# Altium schematic sheet IsolatedPowerSupplyMB-Rev2b.SchDoc(IsolatedPowerSupplyMB-Rev2b)
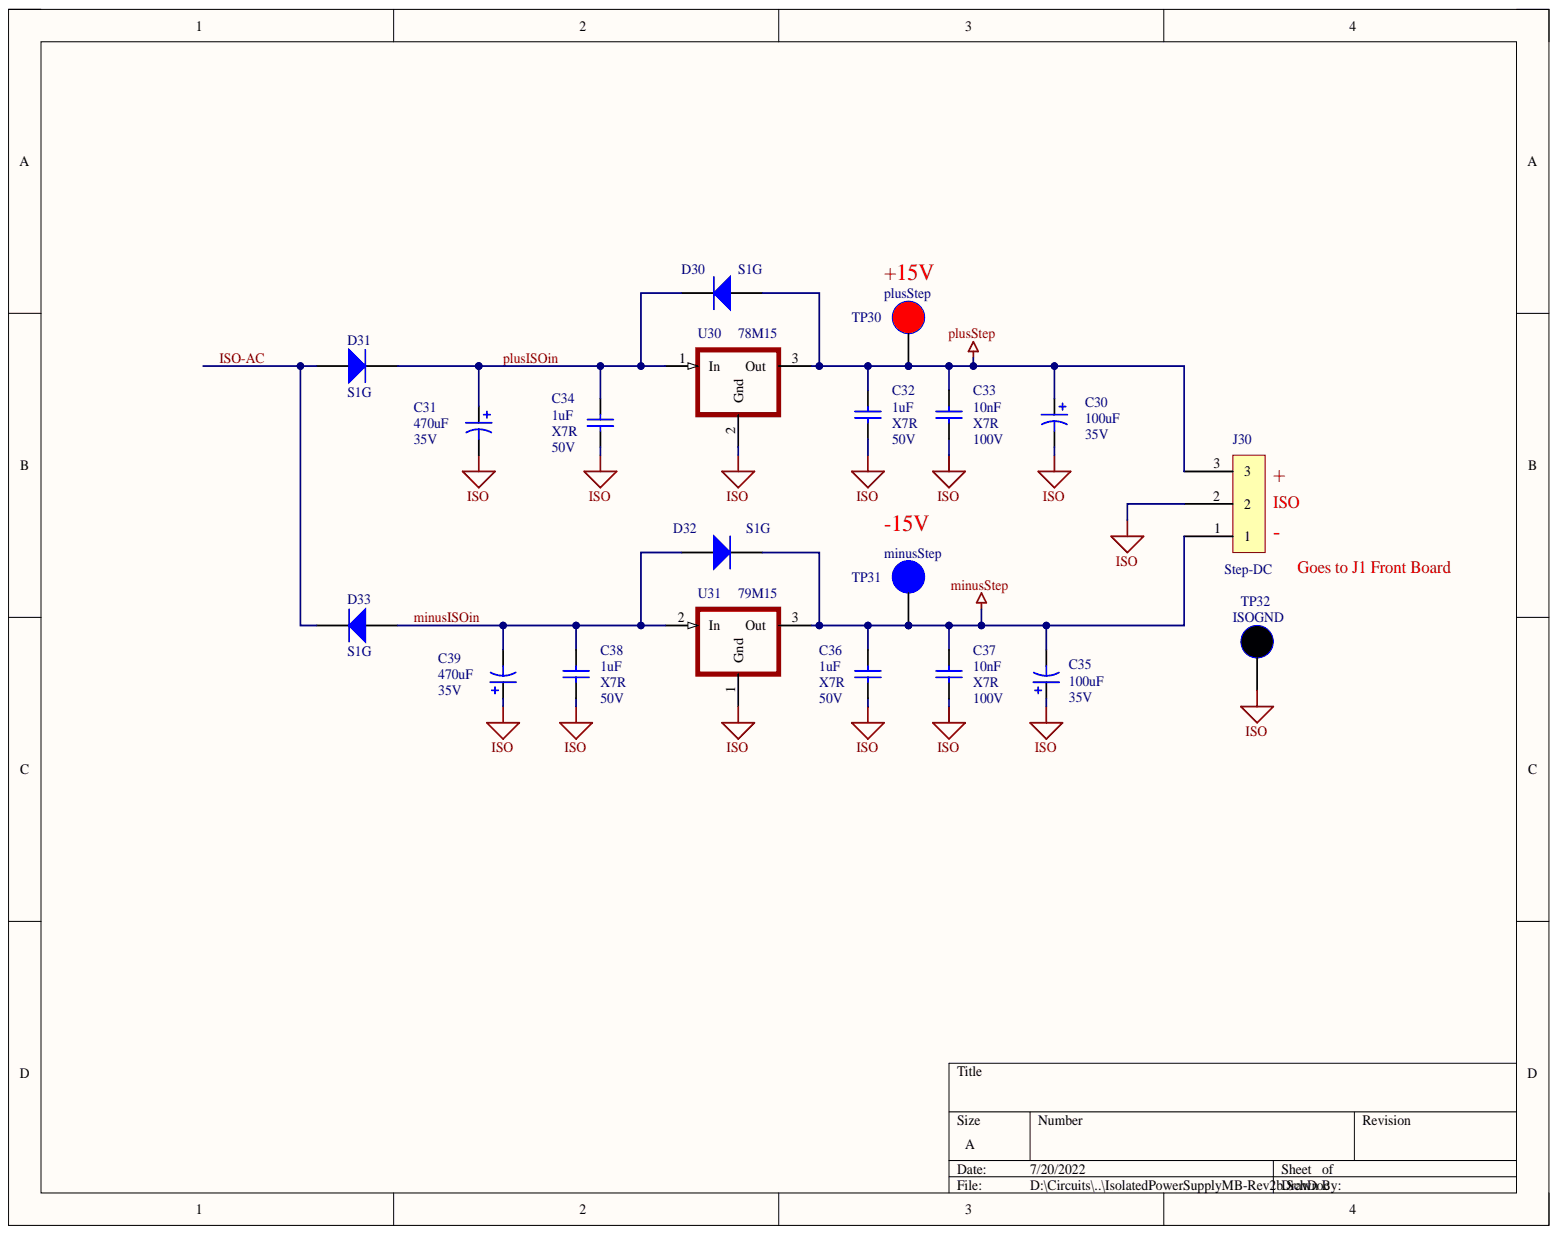
[diagram p0: IsolatedPowerSupplyMB-Rev2b.SchDoc]

## Parts
C30  100uF  Voltage: 35V  [CAPPR2.5-6.3X10]
C31  470uF  [CAPPR5-10x12.5-STEP]
C32  1uF  Dielectric: X7R  Voltage: 50V  [CAPC2012M]
C33  10nF  Dielectric: X7R  Voltage: 100V  [CAPC2012M]
C34  1uF  Dielectric: X7R  Voltage: 50V  [CAPC2012M]
C35  100uF  Voltage: 35V  [CAPPR2.5-6.3X10]
C36  1uF  Dielectric: X7R  [CAPC2012M]
C37  10nF  Dielectric: X7R  Voltage: 100V  [CAPC2012M]
C38  1uF  Dielectric: X7R  Voltage: 50V  [CAPC2012M]
C39  470uF  [CAPPR5-10x12.5-STEP]
D30  S1G  [SMA-D]
D31  S1G  [SMA-D]
D32  S1G  [SMA-D]
D33  S1G  [SMA-D]
J30  Step-DC  [DTA-100-3]
TP30  plusStep  [TP-.038]
TP31  minusStep  [TP-.038]
TP32  ISOGND  [TP-.038]
U30  78M15  [DPAK-M]
U31  79M15  [DPAK-M]

## Nets
ISO: C30.2 C31.2 C32.2 C33.2 C34.2 C35.1 C36.2 C37.2 C38.2 C39.1 J30.2 TP32.1 U30.2 U31.1
minusStep: C35.2 C36.1 C37.1 D32.2 J30.1 TP31.1 U31.3
plusStep: C30.1 C32.1 C33.1 D30.1 J30.3 TP30.1 U30.3
ISO-AC: D31.1 D33.2
minusISOin: C38.1 C39.2 D32.1 D33.1 U31.2
plusISOin: C31.1 C34.1 D30.2 D31.2 U30.1

## Library data of the parts
C30 C35 = Cap Pol1: CAP ALUM 100UF 20% 35V THRU HOLE; Manufacturer: Rubycon; Manufacturer Part Number: 35YXJ100M6.3X11; Impedance: 220mR; Lead Diameter: 0.5mm; Leakage Current: 3uA; Life (Hours): 7000Hour; Polarity: Polar; Ripple Current: 400mA; Capacitance: 100uF; Case/Package: Radial; Component Kind: Standard; Diameter: 6.3mm; Height: 11mm; Height - Seated (Max): 0.492inch; Lead Pitch: 2.5mm; Library Name: Miscellaneous Devices.IntLib; Max Operating Temperature: 105°C; Min Operating Temperature: -40°C; Mount: Through Hole; Package Quantity: 3000; Packaging: Bulk; Radiation Hardening: No; Signal Integrity: Cap Pol; Simulation: CAP; Supplier: Digi-Key; Supplier Part Number: 1189-2260-ND; Termination: Radial; Tolerance: 20%; Value: 100uF; Voltage Rating: 35V
C31 C39 = Cap Pol3: CAP ALUM 470UF 20% 35V RADIAL; Manufacturer: Rubycon; Manufacturer Part Number: 35ZL470MEFC10X20; Dielectric Material: Aluminium; Impedance: 23mR; Lead Diameter: 0.6mm; Leakage Current: 3uA; Life (Hours): 4000Hour; Ripple Current: 1.82A; Capacitance: 470uF; Case/Package: Radial; Component Kind: Standard; Diameter: 10mm; Height: 20mm; Height - Seated (Max): 0.866inch; Lead Free: Lead Free; Lead Pitch: 5mm; Library Name: Miscellaneous Devices.IntLib; Max Operating Temperature: 105°C; Min Operating Temperature: -40°C; Mount: Through Hole; Package Quantity: 1000; Packaging: Bulk; Radiation Hardening: No; REACH SVHC: Unknown; Signal Integrity: Cap Pol; Simulation: CAP; Supplier: Digi-Key; Supplier Part Number: 35ZL470MEFC10X20-ND; Termination: Radial; Tolerance: 20%; Value: 470uF; Voltage: 35V; Voltage Rating: 35V
C32 C34 C36 C38 = Cap: CAP CER 1UF 50V X7R 0805; Manufacturer: Samsung; Manufacturer Part Number: CL21B105KBFNNNE; Dielectric Material: Ceramic; Tolerance: 10%; Capacitance: 1uF; Case Code (Imperial): 0805; Case Code (Metric): 2012; Case/Package: 0805; Component Kind: Standard; Construction: Flat; Depth: 1.25mm; Height: 1.25mm; Length: 2mm; Library Name: Miscellaneous Devices.IntLib; Max Operating Temperature: 125°C; Min Operating Temperature: -55°C; Mount: Surface Mount; Number of Pins: 2; Package Quantity: 1; Packaging: Tape and Reel; Radiation Hardening: No; REACH SVHC: No SVHC; RoHS Compliant: Yes; Series: CL; Signal Integrity: Cap; Simulation: CAP; Supplier: Digi-Key; Supplier Part Number: 1276-1029-6-ND; Termination: SMD/SMT; Thickness: 0.053inch; Value: 1uF; Voltage Rating: 50V; Voltage Rating (DC): 50V; Width: 0.049inch
  C36: Voltage: 50V
C33 C37 = Cap: CAP CER 10NF 100V X7R 0805; Manufacturer: Samsung; Manufacturer Part Number: CL21B103KCANNNC; Dielectric Material: Ceramic; Dissipation Factor: 2.5%; Tolerance: 10%; Capacitance: 10nF; Case Code (Imperial): 0805; Case Code (Metric): 2012; Case/Package: 0805; Component Kind: Standard; Construction: Flat; Contact Plating: Tin; Depth: 1.25mm; Height: 0.65mm; Length: 2mm; Library Name: Miscellaneous Devices.IntLib; Material: Ceramic; Max Operating Temperature: 125°C; Min Operating Temperature: -55°C; Mount: Surface Mount; Number of Pins: 2; Package Quantity: 1; Packaging: Tape and Reel; Radiation Hardening: No; REACH SVHC: No SVHC; RoHS Compliant: Yes; Series: CL; Signal Integrity: Cap; Simulation: CAP; Supplier: Digi-Key; Supplier Part Number: 1276-1249-6-ND; Termination: SMD/SMT; Thickness: 0.03inch; Value: 10nF; Voltage Rating: 100V; Voltage Rating (DC): 100V; Width: 0.049inch
D30-D33 = Diode 1N4002: Diode Switching 400V 1A 2-Pin DO-214AC T/R; Manufacturer: ON Semiconductor / Fairchild; Capacitance: 12pF; Element Configuration: Single; Forward Voltage: 1.1V; Max Forward Surge Current (Ifsm): 30A; Max Surge Current: 40A; Peak Reverse Current: 1uA; Polarity: Standard; Power Dissipation: 1.4W; Recovery Time: 1800ns; Reverse Recovery Time: 1.8us; Average Rectified Current: 1A; Case/Package: SMA; Component Kind: Standard; ComponentLink1Description: Manufacturer Link; ComponentLink1URL: http://www.fairchildsemi.com/; ComponentLink2Description: Datasheet; ComponentLink2URL: http://www.fairchildsemi.com/ds/1N%2F1N4001.pdf; Contact Plating: Tin,Matte; Current Rating: 1A; Forward Current: 1A; Height: 2.2mm; Lead Free: Lead Free; Length: 4.75mm; Library Name: Miscellaneous Devices.IntLib; Manufacturer Part Number: S1G; Max Operating Temperature: 150°C; Max Repetitive Reverse Voltage (Vrrm): 400V; Max Reverse Voltage (DC): 400V; Min Operating Temperature: -55°C; Mount: Surface Mount; Number of Pins: 2; Output Current: 1A; Package Quantity: 1; Packaging: Tape and Reel; Peak Non-Repetitive Surge Current: 30A; Radiation Hardening: No; REACH SVHC: No SVHC; Reverse Voltage: 400V; Signal Integrity: 1N4002; Simulation: 1N4002; Supplier: Digi-Key; Supplier Part Number: S1GFSCT-ND; Value: S1G; Voltage Rating (DC): 400V; Weight: 0.106g; Width: 2.95mm
J30 = 3-Pin: 2.54mm 3 pin; Manufacturer: TE Connectivity; Manufacturer Part Number: 640456-3; Approvals: CSA; Backwall/Post Interruptions: No (Without); Circuit Application: Signal; Connector System: Wire-to-Board; Connector Type: Connector,Pin,Plug,Header; Contact Current Rating: 5A; Contact Post Length: 3.56mm; Contact Resistance: 6mR; Contact Style: Straight; Gender: Male; Mating Connector Lock: Yes (With); Max Voltage Rating (AC): 250V; Number of Contacts: 3; PCB Mount Retention: Without; PCB Mounting Orientation: Vertical; PCB Thickness: 0.063inch; Plating Thickness: 3.81um; Tail Length: 0.14inch; Value: 640456-3; Category: Connectors; Color: Beige,White; Component Kind: Standard; ComponentLink1Description: http://www.farnell.com/datasheets/2043229.pdf?src-supplier=Farnell; ComponentLink1URL: http://www.farnell.com/datasheets/2043229.pdf?src-supplier=Farnell; ComponentLink2Description: http://www.farnell.com/datasheets/2043229.pdf?src-supplier=Element14; ComponentLink2URL: http://www.farnell.com/datasheets/2043229.pdf?src-supplier=Element14; ComponentLink3Description: http://www.farnell.com/datasheets/2043229.pdf?src-supplier=Newark; ComponentLink3URL: http://www.farnell.com/datasheets/2043229.pdf?src-supplier=Newark; ComponentLink4Description: https://www.te.com/commerce/DocumentDelivery/DDEController?Action=srchrtrv&DocNm=640456&DocType=Customer+Drawing&DocLang=English&src-supplier=Digi-Key; ComponentLink4URL: https://www.te.com/commerce/DocumentDelivery/DDEController?Action=srchrtrv&DocNm=640456&DocType=Customer+Drawing&DocLang=English&src-supplier=Digi-Key; ComponentLink5Description: https://datasheet.ciiva.com/11746/0900766b80027008-11746172.pdf?src-supplier=RSComponents; ComponentLink5URL: https://datasheet.ciiva.com/11746/0900766b80027008-11746172.pdf?src-supplier=RSComponents; ComponentLink6Description: https://datasheet.ciiva.com/6005/1712135-6005440.pdf?src-supplier=Farnell; ComponentLink6URL: https://datasheet.ciiva.com/6005/1712135-6005440.pdf?src-supplier=Farnell; ComponentLink7Description: https://datasheet.ciiva.com/19474/613940-19474164.pdf?src-supplier=Jameco; ComponentLink7URL: https://datasheet.ciiva.com/19474/613940-19474164.pdf?src-supplier=Jameco; ComponentLink8Description: https://datasheet.ciiva.com/16456/eng-ds-82056-mta-0608-16456886.pdf?src-supplier=Future+Electronics; ComponentLink8URL: https://datasheet.ciiva.com/16456/eng-ds-82056-mta-0608-16456886.pdf?src-supplier=Future+Electronics; ComponentLink9Description: https://datasheet.ciiva.com/14156/04384301-14156821.pdf?src-supplier=Distrelec; ComponentLink9URL: https://datasheet.ciiva.com/14156/04384301-14156821.pdf?src-supplier=Distrelec; ComponentLink10Description: https://datasheet.ciiva.com/16656/eng-ds-82056-mta-0608-16656523.pdf?src-supplier=Avnet+Europe; ComponentLink10URL: https://datasheet.ciiva.com/16656/eng-ds-82056-mta-0608-16656523.pdf?src-supplier=Avnet+Europe; ComponentLink11Description: https://datasheet.ciiva.com/30127/8852eng-ds-82056-mta-0608-30127480.pdf?src-supplier=Onlinecomponents.com; ComponentLink11URL: https://datasheet.ciiva.com/30127/8852eng-ds-82056-mta-0608-30127480.pdf?src-supplier=Onlinecomponents.com; ComponentLink12Description: https://datasheet.ciiva.com/14637/70083643-14637750.pdf?src-supplier=Allied+Electronics; ComponentLink12URL: https://datasheet.ciiva.com/14637/70083643-14637750.pdf?src-supplier=Allied+Electronics; ComponentLink13Description: https://datasheet.ciiva.com/7128/eng-ds-82056-mta-0608-7128744.pdf?src-supplier=Newark; ComponentLink13URL: https://datasheet.ciiva.com/7128/eng-ds-82056-mta-0608-7128744.pdf?src-supplier=Newark; ComponentLink14Description: https://datasheet.ciiva.com/709/eng-cd-640456-w2-709688.pdf?src-supplier=Digi-Key; ComponentLink14URL: https://datasheet.ciiva.com/709/eng-cd-640456-w2-709688.pdf?src-supplier=Digi-Key; ComponentLink15Description: https://datasheet.ciiva.com/16047/1984976-16047357.pdf?src-supplier=Onlinecomponents.com; ComponentLink15URL: https://datasheet.ciiva.com/16047/1984976-16047357.pdf?src-supplier=Onlinecomponents.com; ComponentLink16Description: https://datasheet.ciiva.com/11758/0900766b811d406d-11758596.pdf?src-supplier=RSComponents; ComponentLink16URL: https://datasheet.ciiva.com/11758/0900766b811d406d-11758596.pdf?src-supplier=RSComponents; ComponentLink17Description: https://datasheet.ciiva.com/26840/getdatasheetpartid-743835-26840596.pdf?src-supplier=Verical; ComponentLink17URL: https://datasheet.ciiva.com/26840/getdatasheetpartid-743835-26840596.pdf?src-supplier=Verical; ComponentLink18Description: https://datasheet.ciiva.com/6752/combiconspring-cagepcbterm-blocks-6752927.pdf?src-supplier=Digi-Key; ComponentLink18URL: https://datasheet.ciiva.com/6752/combiconspring-cagepcbterm-blocks-6752927.pdf?src-supplier=Digi-Key; ComponentLink19Description: https://datasheet.ciiva.com/16051/1988969-16051361.pdf?src-supplier=Onlinecomponents.com; ComponentLink19URL: https://datasheet.ciiva.com/16051/1988969-16051361.pdf?src-supplier=Onlinecomponents.com; ComponentLink20Description: https://datasheet.ciiva.com/823/combiconcompactseries-823768.pdf?src-supplier=Digi-Key; ComponentLink20URL: https://datasheet.ciiva.com/823/combiconcompactseries-823768.pdf?src-supplier=Digi-Key; ComponentLink21Description: https://datasheet.ciiva.com/14609/70055172-14609515.pdf?src-supplier=Allied+Electronics; ComponentLink21URL: https://datasheet.ciiva.com/14609/70055172-14609515.pdf?src-supplier=Allied+Electronics; Contact Finish - Mating: TIN; Contact Gender: Male; Contact Material: Copper Alloy; Contact Mating Length: 7.49mm; Contact Plating: Tin; Contact Retention: No (Without); Contact Shape: Square; Contact Type: Male Pin; Current Rating: 5A; Depth: 5.72mm; ELV: Compliant; Fastening Type: Friction; Flammability Rating: UL94 V-0; Height: 7.87mm; Housing Color: Natural; Housing Material: Polyester; Insulation Color: Natural; Insulation Material: Thermoplastic; Lead Free: Lead Free; Length: 0.4inch; Library Name: MY_CONNECTORS.SchLib; Mating Alignment: No (Without); Mating Retention: No (Without); Max Operating Temperature: 221°C; Min Operating Temperature: -67°C; Mount: Through Hole; Mounting Ears: No (Without); Number of Positions: 3; Number of Rows: 1; Orientation: Straight; Package Quantity: 5000; Packaging: Bulk; Panel Mount Retention: No (Without); PCB Mount Alignment: No (Without); Pitch: 2.54mm; Pricing 1: 1=0.16000, 10=0.13500, 100=0.10360, 500=0.08766, 1000=0.07173, 5000=0.06376, 10000=0.05977 (USD); Radiation Hardening: No; REACH SVHC: Unknown; RoHS: Yes; RoHS Compliant: Yes; Sealable: No (Without); Series: MTA-100; Shrouded: No (Without); Stock 1: 96416; Strain Relief: No (Without); Supplier: Digi-Key; Supplier Part Number: A19470-ND; Termination: Solder; Voltage Rating: 250V; Voltage Rating (AC): 250V
TP30 = TP-Round-Red: TP Thru-Hole; Manufacturer: UW; Manufacturer Part Number: Gold; Component Kind: Standard; Library Name: MY_TP.SchLib; Supplier: UW; Supplier Part Number: Gold; Value: TP Thru-Hole
TP31 = TP-Round-Blue: TP Thru-Hole; Manufacturer: UW; Manufacturer Part Number: Gold; Component Kind: Standard; Library Name: MY_TP.SchLib; Supplier: UW; Supplier Part Num,ber: Gold; Value: TP Thru-Hole
TP32 = TP-Round-Black: TP Thru-Hole; Manufacturer: UW; Manufacturer Part Number: Gold; Component Kind: Standard; Library Name: MY_TP.SchLib; Supplier: UW; Supplier Part Num,ber: Gold; Value: TP Thru-Hole
U30 = 78M00-3PIN: 15V POS  0.5A V REG; Manufacturer: ON Semiconductor; Manufacturer Part Number: MC78M15CDTRKG; Current Rating: 500mA; Dropout Voltage: 2V; Max Input Voltage: 35V; Max Output Voltage: -37V; Min Input Voltage: 17V; Number of Outputs: 1; Output Type: Fixed; Polarity: Positive; Power Supply Rejection Ratio (PSRR): 70dB; Reference Voltage: -1.287V; Case/Package: DPAK; Category: Voltage Regulators - Linear; Component Kind: Standard; ComponentLink1Description: https://datasheet.ciiva.com/15111/getdatasheetpartid-53738-15111156.pdf?src-supplier=Verical; ComponentLink1URL: https://datasheet.ciiva.com/15111/getdatasheetpartid-53738-15111156.pdf?src-supplier=Verical; ComponentLink2Description: https://datasheet.ciiva.com/26903/lm337d2tr4g-26903079.pdf?src-supplier=IIIC; ComponentLink2URL: https://datasheet.ciiva.com/26903/lm337d2tr4g-26903079.pdf?src-supplier=IIIC; ComponentLink3Description: https://datasheet.ciiva.com/2062/lm337-d-2062652.pdf?src-supplier=Digi-Key; ComponentLink3URL: https://datasheet.ciiva.com/2062/lm337-d-2062652.pdf?src-supplier=Digi-Key; ComponentLink4Description: https://datasheet.ciiva.com/2062/lm337-d-2062653.pdf?src-supplier=Digi-Key; ComponentLink4URL: https://datasheet.ciiva.com/2062/lm337-d-2062653.pdf?src-supplier=Digi-Key; ComponentLink5Description: https://datasheet.ciiva.com/2062/lm337-d-2062654.pdf?src-supplier=Digi-Key; ComponentLink5URL: https://datasheet.ciiva.com/2062/lm337-d-2062654.pdf?src-supplier=Digi-Key; ComponentLink6Description: https://datasheet.ciiva.com/4883/lm337-d-109436-4883563.pdf?src-supplier=Mouser; ComponentLink6URL: https://datasheet.ciiva.com/4883/lm337-d-109436-4883563.pdf?src-supplier=Mouser; ComponentLink7Description: https://datasheet.ciiva.com/26177/lm337-d-26177831.pdf?src-supplier=Avnet+Europe; ComponentLink7URL: https://datasheet.ciiva.com/26177/lm337-d-26177831.pdf?src-supplier=Avnet+Europe; Contact Plating: Tin,Matte; Halogen Free: Halogen Free; Height: 2.38mm; Lead Free: Lead Free; Length: 6.73mm; Max Operating Temperature: 125°C; Max Output Current: 500mA; Min Operating Temperature: 0°C; Min Output Voltage: 15V; Mount: Surface Mount; Nominal Output Voltage: 15V; Number of Pins: 3; Number of Regulators: 1; Output Current: 500mA; Output Voltage: 15V; Package Quantity: 1; Packaging: Tape and Reel; Pricing 1: 1=1.47000, 10=1.31000, 25=1.24320, 100=1.02130, 250=0.95468 (USD); Radiation Hardening: No; REACH SVHC: No SVHC; RoHS: Yes; RoHS Compliant: Yes; Series: -; Supplier: Digi-Key; Supplier Part Number: MC78M15CDTRKGOSDKR-ND; Value: 78M15; Width: 6.22mm; Library Name: MY_Vregs.SchLib
U31 = 79M00-3PIN: IC REG LINEAR -15V 500MA DPAK; Manufacturer: ON Semiconductor; Manufacturer Part Number: MC79M15CDTRKG; Accuracy: 4%; Dropout Voltage: 1.1V; Max Input Voltage: -35V; Max Output Voltage: -15.6V; Min Input Voltage: -17V; Number of Outputs: 1; Output Type: Fixed; Polarity: Negative; Power Supply Rejection Ratio (PSRR): 60dB; Case/Package: DPAK; Component Kind: Standard; Contact Plating: Tin,Matte; Halogen Free: Halogen Free; Lead Free: Lead Free; Max Operating Temperature: 125°C; Max Output Current: 500mA; Min Operating Temperature: 0°C; Min Output Voltage: -15V; Mount: Surface Mount; Nominal Output Voltage: -15V; Number of Pins: 3; Number of Regulators: 1; Output Current: 500mA; Output Voltage: -15V; Output Voltage Accuracy: 4%; Package Quantity: 1; Packaging: Tape and Reel; Radiation Hardening: No; REACH SVHC: No SVHC; Supplier: Digi-Key; Supplier Part Number: MC79M15CDTRKGOSDKR-ND; Value: 79M15; Library Name: MY_Vregs.SchLib
